# Altium schematic sheet Power Input and Protection Rev 6a.SchDoc("Power Input and Protection Rev 6a")
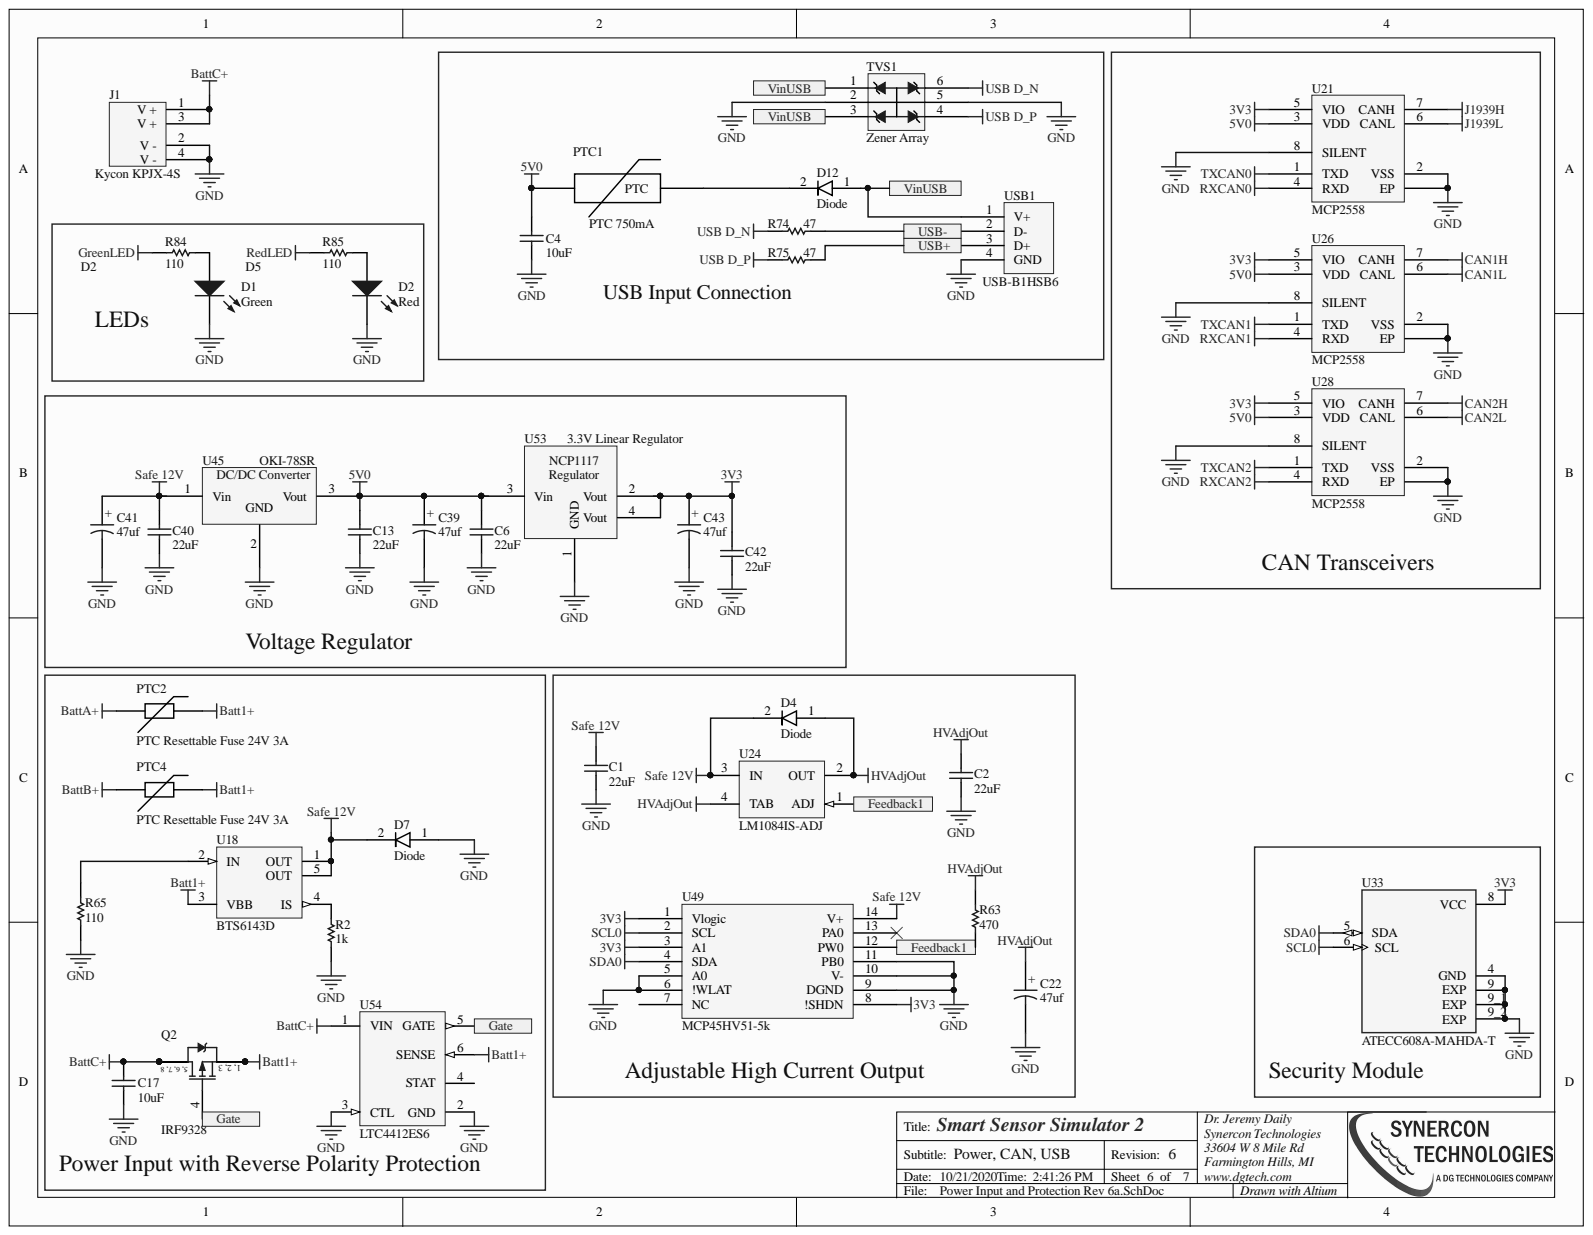
[diagram p0: Power Input and Protection Rev 6a.SchDoc]

## Parts
C1  22uF  [1206]
C2  22uF  [1206]
C4  10uF  [0603]
C6  22uF  [1206]
C13  22uF  [1206]
C17  10uF  [0603]
C22  47uf  [Elec. Cap 2.5 x 6.3mm]
C39  47uf  [Elec. Cap 2.5 x 6.3mm]
C40  22uF  [1206]
C41  47uf  [Elec. Cap 2.5 x 6.3mm]
C42  22uF  [1206]
C43  47uf  [Elec. Cap 2.5 x 6.3mm]
D1  Green  [0805 Polarized]
D2  Red  [0805 Polarized]
D4  Diode  [CST2C]
D7  Diode  [CST2C]
D12  Diode  [CST2C]
J1  Kycon KPJX-4S  [KPJX-4S-S]
PTC1  PTC 750mA  [1206]
PTC2  PTC Resettable Fuse 24V 3A  [2920]
PTC4  PTC Resettable Fuse 24V 3A  [2920]
Q2  IRF9328  [SOIC-8]
R2  1k  [0603]
R63  470  [0603]
R65  110  [0603]
R74  47  [0603]
R75  47  [0603]
R84  110  [0603]
R85  110  [0603]
TVS1  Zener Array  [SOT23-6]
U18  BTS6143D  [INF-PG-TO252-5-11_N]
U21  MCP2558  [TDFN]
U24  LM1084IS-ADJ  [TS3B_M]
U26  MCP2558  [TDFN]
U28  MCP2558  [TDFN]
U33  ATECC608A-MAHDA-T  [SON50P200X300X60-9N]
U45  OKI-78SR  [78xx]
U49  MCP45HV51-5k  [TSSOP-ST14_M]
U53  3.3V Linear Regulator  [SOT-223]
U54  LTC4412ES6  [LT-S6-6-TSOT-23_M]
USB1  USB-B1HSB6  [USB B Connector]

## Ports
Feedback1, Gate, USB+, USB-, VinUSB

## Nets
3V3: C42.2 C43.1 U21.5(VIO) U26.5(VIO) U28.5(VIO) U33.8(VCC) U49.1(Vlogic) U49.3(A1) U49.8(!SHDN) U53.2(Vout) U53.4(Vout)
5V0: C4.1 C6.2 C13.2 C39.1 PTC1.1 U21.3(VDD) U26.3(VDD) U28.3(VDD) U45.3 U53.3(Vin)
Batt1+: PTC2.2 PTC4.2 Q2.1 Q2.2 Q2.3 U18.3(VBB) U54.6(SENSE)
BattA+: PTC2.1
BattB+: PTC4.1
BattC+: C17.1 J1.1(V_+) J1.3(V_+) Q2.5 Q2.6 Q2.7 Q2.8 U54.1(VIN)
CAN1H: U26.7(CANH)
CAN1L: U26.6(CANL)
CAN2H: U28.7(CANH)
CAN2L: U28.6(CANL)
GND: C1.1 C2.1 C4.2 C6.1 C13.1 C17.2 C22.2 C39.2 C40.1 C41.2 C42.1 C43.2 D1.2 D2.2 D7.1 J1.2(V_-) J1.4(V_-) R2.1 R65.1 TVS1.2 TVS1.5 U21.0(EP) U21.2(VSS) U21.8(SILENT) U26.0(EP) U26.2(VSS) U26.8(SILENT) U28.0(EP) U28.2(VSS) U28.8(SILENT) U33.4(GND) U33.9(EXP) U33.9_1(EXP) U33.9_2(EXP) U45.2 U49.5(A0) U49.6(!WLAT) U49.9(DGND) U49.10(V-) U49.11(PB0) U53.1(GND) U54.2(GND) U54.3(CTL) USB1.4(GND)
GreenLED: R84.2
HVAdjOut: C2.2 C22.1 D4.1 R63.1 U24.2(OUT) U24.4(TAB)
J1939H: U21.7(CANH)
J1939L: U21.6(CANL)
RedLED: R85.2
RXCAN0: U21.4(RXD)
RXCAN1: U26.4(RXD)
RXCAN2: U28.4(RXD)
Safe 12V: C1.2 C40.2 C41.1 D4.2 D7.2 U18.1(OUT) U18.5(OUT) U24.3(IN) U45.1 U49.14(V+)
SCL0: U33.6(SCL) U49.2(SCL)
SDA0: U33.5(SDA) U49.4(SDA)
TXCAN0: U21.1(TXD)
TXCAN1: U26.1(TXD)
TXCAN2: U28.1(TXD)
USB D_N: R74.1 TVS1.6
USB D_P: R75.1 TVS1.4
Feedback1: R63.2 U24.1(ADJ) U49.12(PW0) ; port Feedback1
Gate: Q2.4 U54.5(GATE) ; port Gate
VinUSB: D12.1 TVS1.1 TVS1.3 USB1.1(V+) ; port VinUSB
NetD1_1: D1.1 R84.1
NetD2_1: D2.1 R85.1
NetD12_2: D12.2 PTC1.2
NetR2_2: R2.2 U18.4(IS)
NetR65_2: R65.2 U18.2(IN)
NetR74_2: R74.2 USB1.2(D-)
NetR75_2: R75.2 USB1.3(D+)

Not connected: U49 7(NC) 13(PA0); U54 4(STAT)

## Library data of the parts
C1 C2 C6 C13 C40 C42 = Cap: 22µF ±20% 25V Ceramic Capacitor X5R 1206 (3216 Metric); PartNumber: GRT31CR61E226ME01L; Manufacturer: Murata Electronics North America; Supplier Part Number 1: 490-12451-1-ND; Supplier 1: Digi-Key; Simulation: CAP; Signal Integrity: Cap; Library Name: SSS Integrated Library.IntLib; Component Kind: Standard
C4 C17 = Cap: 10µF ±20% 25V Ceramic Capacitor X5R 0603 (1608 Metric); PartNumber: GRT188R61E106ME13D; Manufacturer: Murata Electronics North America; Supplier Part Number 1: 490-12323-1-ND; Supplier 1: Digi-Key; Simulation: CAP; Signal Integrity: Cap; Library Name: SSS Integrated Library.IntLib; Component Kind: Standard
C22 C39 C41 C43 = Electrolytic Cap: 47µF 50V Aluminum Electrolytic Capacitors Radial, Can  5000 Hrs @ 105°C; PartNumber: 50YXF47MEFC6.3X11; Manufacturer: Rubycon; Supplier Part Number 1: 1189-1854-ND; Supplier 1: Digi-Key; Library Name: SSS Integrated Library.IntLib; Component Kind: Standard
D1 = LED - Green: Green 570nm LED Indication - Discrete 2.2V 0805 (2012 Metric); PartNumber: LG R971-KN-1; Manufacturer: OSRAM Opto Semiconductors Inc.; Supplier Part Number 1: 475-1410-1-ND; Supplier 1: Digi-Key; Library Name: SSS Integrated Library.IntLib; Component Kind: Standard
D2 = LED - Red: Red 633nm LED Indication - Discrete 2V 0805 (2012 Metric); PartNumber: LS R976-NR-1; Manufacturer: OSRAM Opto Semiconductors Inc.; Supplier Part Number 1: 475-1278-1-ND; Supplier 1: Digi-Key; Library Name: SSS Integrated Library.IntLib; Component Kind: Standard
D4 D7 D12 = Diode: Diode Schottky 40V 2A Surface Mount DO-214AC (SMA); PartNumber: CCS15S30L3FCT-ND; Manufacturer: Toshiba Semiconductor and Storage; Supplier Part Number 1: CCS15S30L3FCT-ND; Supplier 1: Digi-Key; Simulation: DIODE; Signal Integrity: Diode; Library Name: SSS Integrated Library.IntLib; Component Kind: Standard
J1 = Power Entry Jack - 4 Pin: 4 (Power) Position Circular Connector Jack, Female Sockets Solder; Manufacturer: Kycon, Inc.; Supplier Part Number 1: 2092-KPJX-4S-S-ND; Supplier 1: Digi-Key; PartNumber: KPJX-4S-S; Library Name: SSS Integrated Library.IntLib; Component Kind: Standard
PTC1 = PTC: PTC RESTTBLE 0.75A 16V CHIP 1206; PartNumber: 0ZCJ0075AF2E; Manufacturer: Bel Fuse Inc; Supplier Part Number 1: 507-1805-1-ND; Supplier 1: Digi-Key; Library Name: SSS Schematic Library.SchLib; Component Kind: Standard
PTC2 PTC4 = PTC: PTC Resettable Fuse 24V 3A Ih Surface Mount 2920 (7351 Metric), Concave; PartNumber: MF-LSMF300/24X-2; Manufacturer: Bourns Inc.; Supplier Part Number 1: MF-LSMF300/24X-2CT-ND; Supplier 1: Digi-Key; Library Name: SSS Integrated Library.IntLib; Component Kind: Standard
Q2 = IRF9328 P-FET: MOSFET P-CH 30V 12A 8-SOIC; PartNumber: IRF9328TRPBF; Manufacturer: Infineon Technologies; Supplier Part Number 1: IRF9328TRPBFCT-ND; Supplier 1: Digi-Key; Library Name: SSS Integrated Library.IntLib; Component Kind: Standard
R2 = Chip Resistor: RES SMD 1K OHM 1% 1/10W 0603; PartNumber: ERJ-3EKF1001V; Manufacturer: Panasonic Electronic Components; Alternative: Acceptable; Supplier Part Number 1: P1.00KHCT-ND; Supplier 1: Digi-Key; Library Name: SSS Schematic Library.SchLib; Component Kind: Standard
R63 = Chip Resistor: RES SMD 470 OHM 1% 1/10W 0603; PartNumber: ERJ-3EKF4700V; Manufacturer: Panasonic Electronic Components; Supplier Part Number 1: P470HCT-ND; Supplier 1: Digi-Key; Library Name: SSS Integrated Library.IntLib; Component Kind: Standard
R65 R84 R85 = Chip Resistor: RES SMD 110 OHM 1% 1/10W 0603; PartNumber: ERJ-3EKF1100V; Manufacturer: Panasonic Electronic Components; Supplier Part Number 1: P110HCT-ND; Supplier 1: Digi-Key; Library Name: SSS Integrated Library.IntLib; Component Kind: Standard
R74 R75 = Chip Resistor: 47 Ohms ±5% 0.25W, 1/4W Chip Resistor 0603 (1608 Metric) Automotive AEC-Q200, Pulse Withstanding Thick Film; PartNumber: ERJ-PA3J470V; Manufacturer: Panasonic Electronic Components; Supplier Part Number 1: P47.00BZCT-ND; Supplier 1: Digi-Key; Library Name: SSS Integrated Library.IntLib; Component Kind: Standard
TVS1 = TVS Diode Array: TVS DIODE 5VWM 6.8VC SOT23; PartNumber: 82402304; Manufacturer: Wurth Electronics Inc; Supplier Part Number 1: 732-4476-1-ND; Supplier 1: Digi-Key; Library Name: Protection Devices.IntLib; Component Kind: Standard
U18 = BTS6143D: PROFET Smart Highside Power Switch, 1 Channel, 10 A Load Current, -40 to 150 degC, PG-TO252-5-11, Reel, Green; PartNumber: BTS6143DAUMA1; Manufacturer: Infineon; Supplier Part Number 1: BTS6143DINCT-ND; Supplier 1: Digi-Key; Library Name: Infineon_Power_Smart_Low-Side_&_High-Side_Switches.IntLib; Component Kind: Standard
U21 = MCP2558FD: Microchip CAN FD Transceiver with Silent Mode; PartNumber: MCP2558FDT-H/MNY; Manufacturer: Microchip Technology; Supplier Part Number 1: MCP2558FDT-H/MNYCT-ND; Supplier 1: Digi-Key; Library Name: TU Custom Parts Schematics.SchLib; Component Kind: Standard
U24 = LM1084IS-ADJ: Linear Voltage Regulator IC Positive Adjustable 1 Output 1.2 V ~ 15 V 5A DDPAK/TO-263-3; PartNumber: LM1084IS-ADJ/NOPB; Manufacturer: Texas Instruments; Supplier Part Number 1: LM1084IS-ADJ/NOPB-ND; Supplier 1: Digi-Key; Library Name: National Semiconductor LDO.IntLib; Component Kind: Standard
U26 U28 = MCP2558FD: Microchip CAN FD Transceiver with Silent Mode; PartNumber: MCP2558FDT-H/MNY; Supplier Part Number 1: MCP2558FDT-H/MNYCT-ND; Supplier 1: Digi-Key; Manufacturer: Microchip; Library Name: TU Custom Parts Schematics.SchLib; Component Kind: Standard
U33 = ATECC608A-MAHDA-T: Authentication Chip 8-UDFN _2x3_; Manufacturer: Microchip Technology; Supplier Part Number 1: ATECC608A-MAHDA-TCT-ND; Supplier 1: Digi-Key; PartNumber: ATECC608A-MAHDA-T; Library Name: ATECC608A-MAHDA-T.IntLib; Component Kind: Standard
U45 = 7805: Linear Regulator Replacement DC DC Converter 1 Output 5V 1.5A 7V - 36V Input; PartNumber: OKI-78SR-5/1.5-W36-C; Manufacturer: Murata Power Solutions; Supplier Part Number 1: 811-2196-5-ND; Supplier 1: Digi-Key; Library Name: SSS Integrated Library.IntLib; Component Kind: Standard
U49 = MCP45HVX1 Digital Pot: Digital Potentiometer 5k Ohm 1 Circuit 256 Taps I²C Interface 14-TSSOP; PartNumber: MCP45HV51-502E/ST; Manufacturer: Microchip Technology; Supplier Part Number 1: MCP45HV51-502E/ST-ND; Supplier 1: Digi-Key; Library Name: SSS Integrated Library.IntLib; Component Kind: Standard
U53 = NCP1117 2.5V: Linear Voltage Regulator IC Positive Fixed 1 Output 3.3V 1A SOT-223; PartNumber: NCP1117ST33T3G; Manufacturer: ON Semiconductor; Supplier Part Number 1: NCP1117ST33T3GOSCT-ND; Supplier 1: Digi-Key; Library Name: SSS Integrated Library.IntLib; Component Kind: Standard
U54 = LTC4412ES6: Low Loss PowerPath(TM) Controller, 2.5 to 28 V Vin, 6-pin SOT23 (S6-6), -40 to 85 degC; Manufacturer: Linear Technology; Supplier Part Number 1: LTC4412ES6#TRPBFCT-ND; Supplier 1: Digi-Key; PartNumber: LTC4412ES6; Library Name: Linear Technology Power Management Power Control.IntLib; Component Kind: Standard
USB1 = USB-Rugged: USB - B Receptacle Connector 4 Position Through Hole, Right Angle, Horizontal; Manufacturer: On Shore Technology Inc.; Supplier Part Number 1: ED2983-ND; Supplier 1: Digi-Key; PartNumber: USB-B1HSB6; Library Name: SSS Integrated Library.IntLib; Component Kind: Standard
